# Altium schematic sheet PCIe_Switch.SchDoc
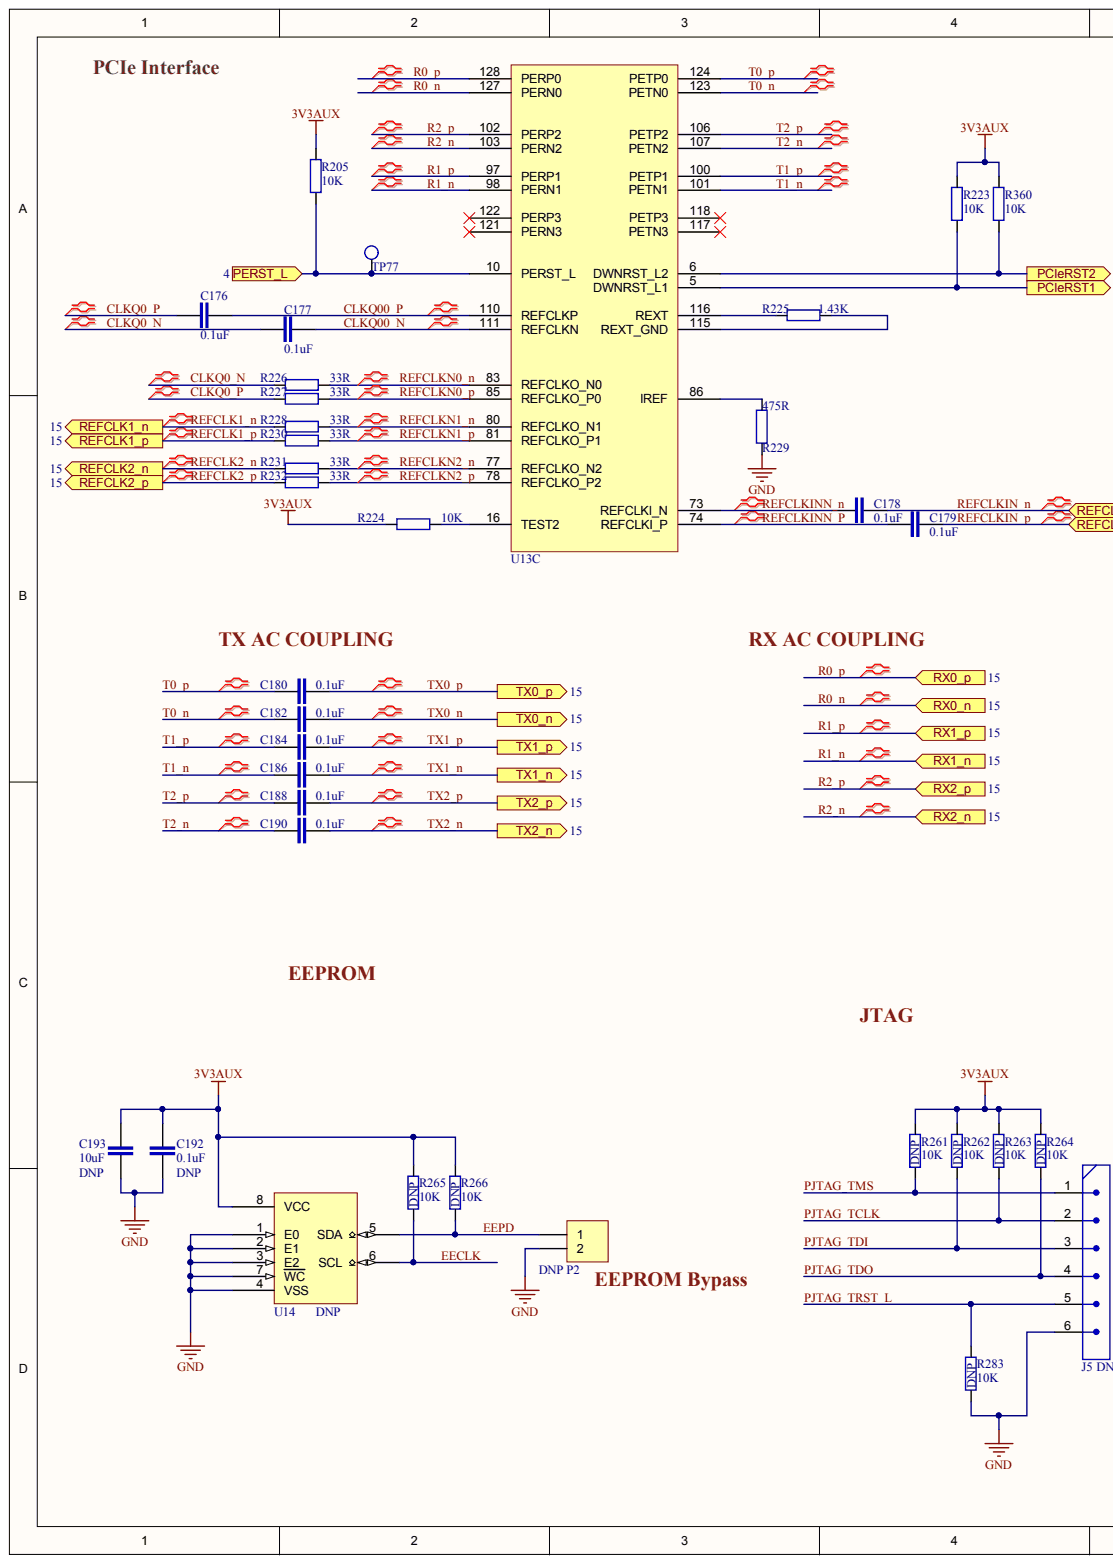
[diagram p0: PCIe_Switch.SchDoc, left]
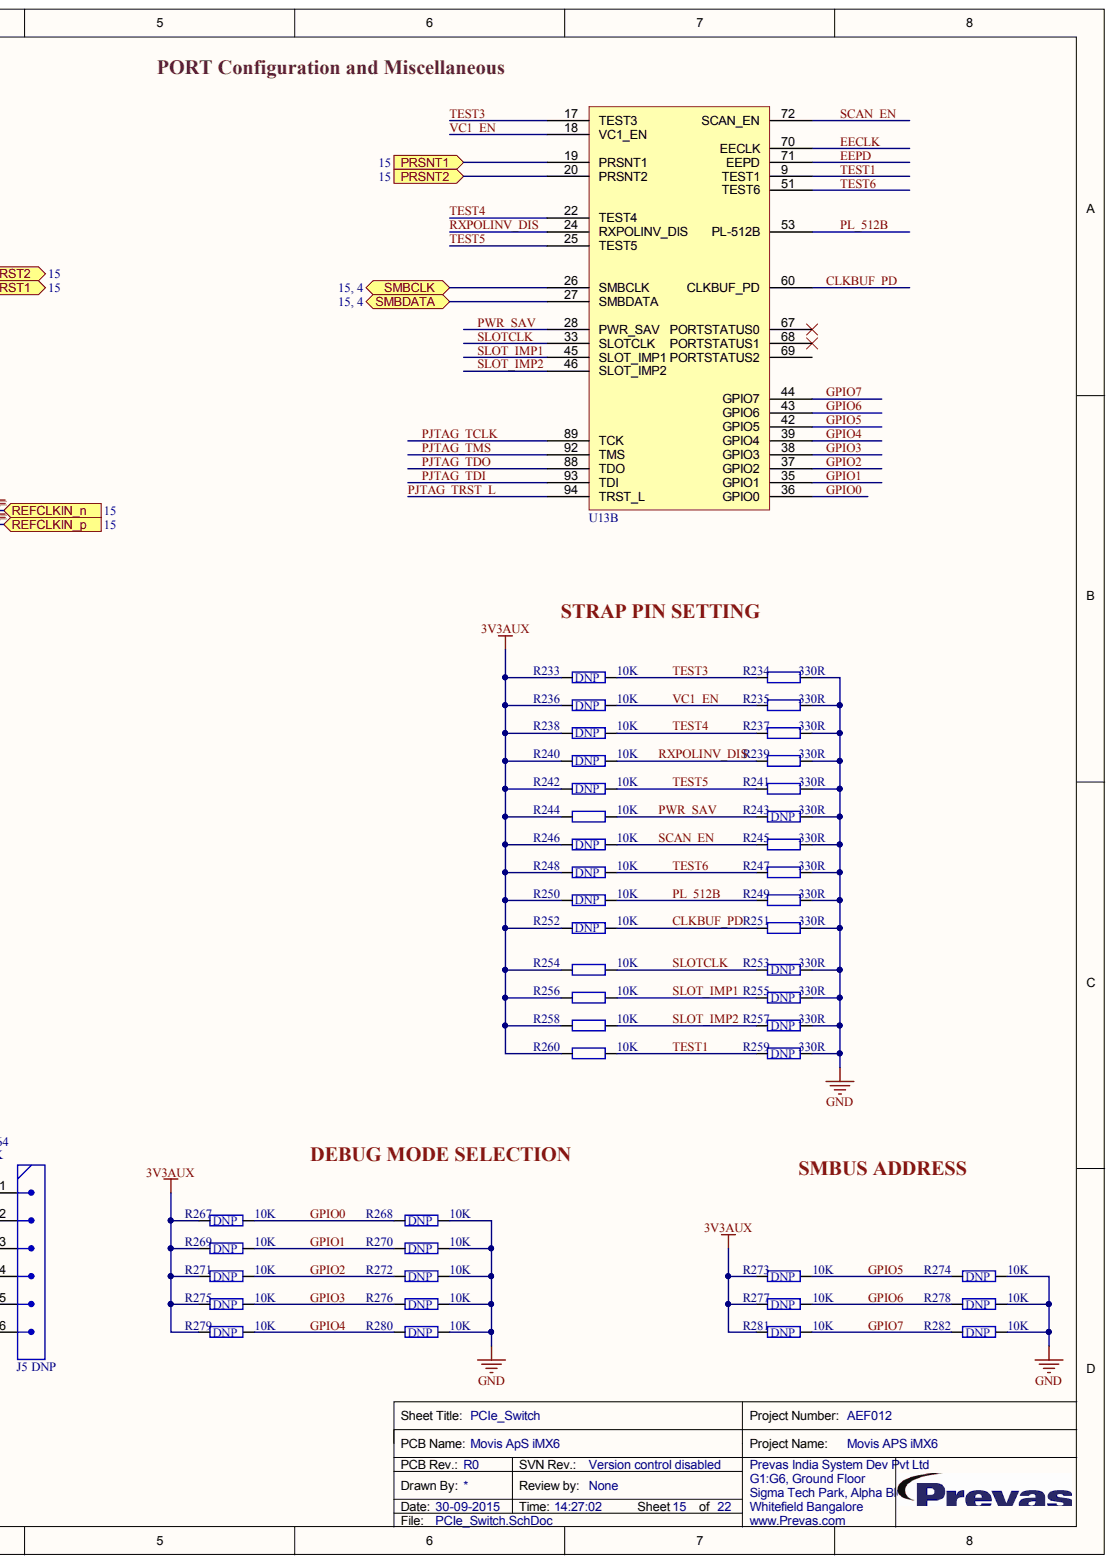
[diagram p1: PCIe_Switch.SchDoc, right]

## Parts
C176  0.1uF  [CAPC1005X55N]
C177  0.1uF  [CAPC1005X55N]
C178  0.1uF  [CAPC1005X55N]
C179  0.1uF  [CAPC1005X55N]
C180  0.1uF  [CAPC1005X55N]
C182  0.1uF  [CAPC1005X55N]
C184  0.1uF  [CAPC1005X55N]
C186  0.1uF  [CAPC1005X55N]
C188  0.1uF  [CAPC1005X55N]
C190  0.1uF  [CAPC1005X55N]
C192  0.1uF  Fitted: DNP  [CAPC1005X55N]
C193  10uF  Fitted: DNP  [CAPC1608X95N]
J5  Fitted: DNP  [MOLEX_53398-6]  (hidden comment: HDR_6)
P2  Fitted: DNP  [MOLEX_53398-2]  (hidden comment: 533980271)
R205  10K  [RESC1608X55N]
R223  10K  [RESC1608X55N]
R224  10K  [RESC1608X55N]
R225  1.43K  [RESC1608X55N]
R226  33R  [RESC1608X55N]
R227  33R  [RESC1608X55N]
R228  33R  [RESC1608X55N]
R229  475R  [RESC1608X55N]
R230  33R  [RESC1608X55N]
R231  33R  [RESC1608X55N]
R232  33R  [RESC1608X55N]
R233  10K  Fitted: DNP  [RESC1608X55N]
R234  330R  [RESC1608X55N]
R235  330R  [RESC1608X55N]
R236  10K  Fitted: DNP  [RESC1608X55N]
R237  330R  [RESC1608X55N]
R238  10K  Fitted: DNP  [RESC1608X55N]
R239  330R  [RESC1608X55N]
R240  10K  Fitted: DNP  [RESC1608X55N]
R241  330R  [RESC1608X55N]
R242  10K  Fitted: DNP  [RESC1608X55N]
R243  330R  Fitted: DNP  [RESC1608X55N]
R244  10K  [RESC1608X55N]
R245  330R  [RESC1608X55N]
R246  10K  Fitted: DNP  [RESC1608X55N]
R247  330R  [RESC1608X55N]
R248  10K  Fitted: DNP  [RESC1608X55N]
R249  330R  [RESC1608X55N]
R250  10K  Fitted: DNP  [RESC1608X55N]
R251  330R  [RESC1608X55N]
R252  10K  Fitted: DNP  [RESC1608X55N]
R253  330R  Fitted: DNP  [RESC1608X55N]
R254  10K  [RESC1608X55N]
R255  330R  Fitted: DNP  [RESC1608X55N]
R256  10K  [RESC1608X55N]
R257  330R  Fitted: DNP  [RESC1608X55N]
R258  10K  [RESC1608X55N]
R259  330R  Fitted: DNP  [RESC1608X55N]
R260  10K  [RESC1608X55N]
R261  10K  Fitted: DNP  [RESC1608X55N]
R262  10K  Fitted: DNP  [RESC1608X55N]
R263  10K  Fitted: DNP  [RESC1608X55N]
R264  10K  Fitted: DNP  [RESC1608X55N]
R265  10K  Fitted: DNP  [RESC1608X55N]
R266  10K  Fitted: DNP  [RESC1608X55N]
R267  10K  Fitted: DNP  [RESC1608X55N]
R268  10K  Fitted: DNP  [RESC1608X55N]
R269  10K  Fitted: DNP  [RESC1608X55N]
R270  10K  Fitted: DNP  [RESC1608X55N]
R271  10K  Fitted: DNP  [RESC1608X55N]
R272  10K  Fitted: DNP  [RESC1608X55N]
R273  10K  Fitted: DNP  [RESC1608X55N]
R274  10K  Fitted: DNP  [RESC1608X55N]
R275  10K  Fitted: DNP  [RESC1608X55N]
R276  10K  Fitted: DNP  [RESC1608X55N]
R277  10K  Fitted: DNP  [RESC1608X55N]
R278  10K  Fitted: DNP  [RESC1608X55N]
R279  10K  Fitted: DNP  [RESC1608X55N]
R280  10K  Fitted: DNP  [RESC1608X55N]
R281  10K  Fitted: DNP  [RESC1608X55N]
R282  10K  Fitted: DNP  [RESC1608X55N]
R283  10K  Fitted: DNP  [RESC1608X55N]
R360  10K  [RESC1608X55N]
TP77  Testpoint  [TP_SMD]  (value not drawn)  (no BOM)
U13  PI7C9X2G304_SCH  [LQFP40P1600X1600X160_HS-129N]  (value not drawn)  (parts B,C)
U14  Fitted: DNP  [SOP65P640X110-8N]  (hidden comment: M24C02-RDW6T)

## Ports
PCIERST1, PCIERST2, PERST_L, PRSNT1, PRSNT2, REFCLK1_N, REFCLK1_P, REFCLK2_N, REFCLK2_P, REFCLKIN_N, REFCLKIN_P, RX0_N, RX0_P, RX1_N, RX1_P, RX2_N, RX2_P, SMBCLK, SMBDATA, TX0_N, TX0_P, TX1_N, TX1_P, TX2_N, TX2_P

## Nets
3V3AUX: C192.2 C193.2 R205.1 R223.1 R224.2 R233.1 R236.1 R238.1 R240.1 R242.1 R244.1 R246.1 R248.1 R250.1 R252.1 R254.1 R256.1 R258.1 R260.1 R261.1 R262.1 R263.1 R264.1 R265.1 R266.1 R267.1 R269.1 R271.1 R273.1 R275.1 R277.1 R279.1 R281.1 R360.1 U14.8(VCC)
GND: C192.1 C193.1 J5.6 P2.2 R229.1 R234.2 R235.2 R237.2 R239.2 R241.2 R243.2 R245.2 R247.2 R249.2 R251.2 R253.2 R255.2 R257.2 R259.2 R268.2 R270.2 R272.2 R274.2 R276.2 R278.2 R280.2 R282.2 R283.2 U14.1(E0) U14.2(E1) U14.3(E2) U14.4(VSS) U14.7(WC)
CLKBUF_PD: R251.1 R252.2 U13.60(CLKBUF_PD)
CLKQ00_N: C177.1 U13.111(REFCLKN)
CLKQ0_N: C177.2 R226.1
CLKQ00_P: C176.1 U13.110(REFCLKP)
CLKQ0_P: C176.2 R227.1
EECLK: R265.2 U13.70(EECLK) U14.6(SCL)
EEPD: P2.1 R266.2 U13.71(EEPD) U14.5(SDA)
GPIO0: R267.2 R268.1 U13.36(GPIO0)
GPIO1: R269.2 R270.1 U13.35(GPIO1)
GPIO2: R271.2 R272.1 U13.37(GPIO2)
GPIO3: R275.2 R276.1 U13.38(GPIO3)
GPIO4: R279.2 R280.1 U13.39(GPIO4)
GPIO5: R273.2 R274.1 U13.42(GPIO5)
GPIO6: R277.2 R278.1 U13.43(GPIO6)
GPIO7: R281.2 R282.1 U13.44(GPIO7)
PERST_L: R205.2 TP77.1 U13.10(PERST_L) ; port PERST_L
PJTAG_TCLK: J5.2 R263.2 U13.89(TCK)
PJTAG_TDI: J5.3 R262.2 U13.93(TDI)
PJTAG_TDO: J5.4 R264.2 U13.88(TDO)
PJTAG_TMS: J5.1 R261.2 U13.92(TMS)
PJTAG_TRST_L: J5.5 R283.1 U13.94(TRST_L)
PL_512B: R249.1 R250.2 U13.53(PL-512B)
PRSNT1: U13.19(PRSNT1) ; port PRSNT1
PRSNT2: U13.20(PRSNT2) ; port PRSNT2
PWR_SAV: R243.1 R244.2 U13.28(PWR_SAV)
R0_N: U13.127(PERN0) ; port RX0_N
R0_P: U13.128(PERP0) ; port RX0_P
R1_N: U13.98(PERN1) ; port RX1_N
R1_P: U13.97(PERP1) ; port RX1_P
R2_N: U13.103(PERN2) ; port RX2_N
R2_P: U13.102(PERP2) ; port RX2_P
REFCLK1_N: R228.1 ; port REFCLK1_N
REFCLK1_P: R230.1 ; port REFCLK1_P
REFCLK2_N: R231.1 ; port REFCLK2_N
REFCLK2_P: R232.1 ; port REFCLK2_P
REFCLKIN_N: C178.1 ; port REFCLKIN_N
REFCLKIN_P: C179.1 ; port REFCLKIN_P
REFCLKINN_N: C178.2 U13.73(REFCLKI_N)
REFCLKINN_P: C179.2 U13.74(REFCLKI_P)
REFCLKN0_N: R226.2 U13.83(REFCLKO_N0)
REFCLKN0_P: R227.2 U13.85(REFCLKO_P0)
REFCLKN1_N: R228.2 U13.80(REFCLKO_N1)
REFCLKN1_P: R230.2 U13.81(REFCLKO_P1)
REFCLKN2_N: R231.2 U13.77(REFCLKO_N2)
REFCLKN2_P: R232.2 U13.78(REFCLKO_P2)
RXPOLINV_DIS: R239.1 R240.2 U13.24(RXPOLINV_DIS)
SCAN_EN: R245.1 R246.2 U13.72(SCAN_EN)
SLOT_IMP1: R255.1 R256.2 U13.45(SLOT_IMP1)
SLOT_IMP2: R257.1 R258.2 U13.46(SLOT_IMP2)
SLOTCLK: R253.1 R254.2 U13.33(SLOTCLK)
SMBCLK: U13.26(SMBCLK) ; port SMBCLK
SMBDATA: U13.27(SMBDATA) ; port SMBDATA
T0_N: C182.2 U13.123(PETN0)
T0_P: C180.2 U13.124(PETP0)
T1_N: C186.2 U13.101(PETN1)
T1_P: C184.2 U13.100(PETP1)
T2_N: C190.2 U13.107(PETN2)
T2_P: C188.2 U13.106(PETP2)
TEST1: R259.1 R260.2 U13.9(TEST1)
TEST3: R233.2 R234.1 U13.17(TEST3)
TEST4: R237.1 R238.2 U13.22(TEST4)
TEST5: R241.1 R242.2 U13.25(TEST5)
TEST6: R247.1 R248.2 U13.51(TEST6)
TX0_N: C182.1 ; port TX0_N
TX0_P: C180.1 ; port TX0_P
TX1_N: C186.1 ; port TX1_N
TX1_P: C184.1 ; port TX1_P
TX2_N: C190.1 ; port TX2_N
TX2_P: C188.1 ; port TX2_P
VC1_EN: R235.1 R236.2 U13.18(VC1_EN)
NetR223_2: R223.2 U13.5(DWNRST_L1) ; port PCIERST1
NetR224_1: R224.1 U13.16(TEST2)
NetR225_1: R225.1 U13.116(REXT)
NetR225_2: R225.2 U13.115(REXT_GND)
NetR229_2: R229.2 U13.86(IREF)
NetR360_2: R360.2 U13.6(DWNRST_L2) ; port PCIERST2

Not connected: U13 67(PORTSTATUS0) 68(PORTSTATUS1) 69(PORTSTATUS2) 117(PETN3) 118(PETP3) 121(PERN3) 122(PERP3)

## Library data of the parts
C176-C180 C182 C184 C186 C188 C190 C192 = INNISI-73: CAP CER 0.1UF 50V 10% X5R 0402; ref_part_type: /capacitor/non_polarized/ceramic/; source_manufacturer_1: TDK Corporation; source_manufacturer_1_pn: C1005X5R1H104K050BB; cae_altium_pcb_status: Prototype; Database Table Name: altium_default; db_rev: 7569; elec_temp_range: -55 to +85 C; phy_height: 0.55mm; phy_mounting: SMD; phy_packaging_type: 0402; ref_datasheet: INNISI-71; cae_altium_sch_status: Prototype; Component Kind: Standard; ComponentLink1Description: Datasheet; ComponentLink1URL: https://cop201.prevas.dk/viewvc/datasheet/INNISI-71.pdf?root=hw_components&view=co; db_created: 2015-08-03 03:34:59.0; db_last_updated: 03-08-2015 03:35:01; db_local_id: INNISI-73; db_status: Prototype; elec_tolerance: ±10%; elec_value: 0.1uF; elec_voltage_rating: 50V; phy_material: X5R; ref_keyword: CAP CER 0.1UF 50V 10% X5R 0402; Library Name: altium_mysql.dblib
C193 = INNISI-78: CAP CER 10UF 16V 10% X5R 0603; ref_part_type: /capacitor/non_polarized/ceramic/; source_manufacturer_1: Murata; source_manufacturer_1_pn: GRM188R61C106KAALD; cae_altium_pcb_status: Prototype; Database Table Name: altium_default; db_rev: 7574; elec_temp_range: -55 to +85C; phy_height: 0.95mm; phy_mounting: SMD; phy_packaging_type: 0603; ref_datasheet: INNISI-75; ref_keyword: Ceramic capacitor X5R 0603; cae_altium_sch_status: Prototype; Component Kind: Standard; ComponentLink1Description: Datasheet; ComponentLink1URL: https://cop201.prevas.dk/viewvc/datasheet/INNISI-75.pdf?root=hw_components&view=co; db_created: 2015-08-03 05:08:42.0; db_last_updated: 03-08-2015 05:08:44; db_local_id: INNISI-78; db_status: Prototype; elec_tolerance: 10%; elec_value: 10uF; elec_voltage_rating: 16V; phy_material: X5R; Library Name: altium_mysql.dblib
J5 = SOMO-114: PicoBlade header 6-pin; ref_part_type: /connector/6 pins/; source_manufacturer_1: Molex; cae_altium_pcb_status: Prototype; Database Table Name: altium_default; db_rev: 4602; elec_current_rating: 1A; elec_temp_range: -40 to +85°C; elec_value: 533980671; elec_voltage_rating: 125V; phy_height: 4.7mm; phy_material: High temperature thermoplastic; phy_mounting: SMD; phy_packaging_type: Vertical; ref_datasheet: MITO-22; cae_altium_sch_status: Prototype; Component Kind: Standard; ComponentLink1Description: Datasheet; ComponentLink1URL: https://cop201.prevas.dk/viewvc/datasheet/MITO-22.pdf?root=hw_components&view=co; db_created: 2012-05-09 15:21:49.0; db_last_updated: 09-05-2012 16:30:37; db_local_id: SOMO-114; db_status: Prototype; ref_keyword: Header; source_manufacturer_1_pn: 533980671; Library Name: altium_mysql.dblib
P2 = MITO-27: PicoBlade header 2-pin; ref_part_type: /connector/2 pins/; source_manufacturer_1: Molex; cae_altium_pcb_status: Prototype; Database Table Name: altium_default; db_rev: 1871; elec_current_rating: 1A; elec_temp_range: -40 to +85°C; elec_voltage_rating: 125V; phy_height: 4.7mm; phy_material: High temperature thermoplastic; phy_mounting: SMD; phy_packaging_type: Vertical; ref_datasheet: MITO-21; cae_altium_sch_status: Prototype; Component Kind: Standard; ComponentLink1Description: Datasheet; ComponentLink1URL: https://cop201.prevas.dk/viewvc/datasheet/MITO-21.pdf?root=hw_components&view=co; db_created: 2008-10-13 12:04:23; db_last_updated: 16-10-2009 09:56:08; db_local_id: MITO-27; db_status: Prototype; elec_value: 533980271; ref_keyword: Header; source_manufacturer_1_pn: 533980271; Library Name: altium_mysql.dblib
R205 R223 R224 R233 R236 R238 R240 R242 R244 R246 R248 R250 R252 R254 R256 R258 R260-R283 R360 = INVIHA-3: RES SMD 10K OHM 1% 1/10W 0603; ref_part_type: /resistor/fixed/; source_manufacturer_1: Yageo; source_manufacturer_1_pn: RC0603FR-0710KL; cae_altium_pcb_status: Prototype; Database Table Name: altium_default; db_rev: 7575; elec_power_rating: 0.1W; elec_temp_range: -55 to +155° C; phy_height: 0.55mm; phy_packaging_type: 0603; ref_datasheet: INVIHA-2; ref_keyword: Chip Resistor; cae_altium_sch_status: Prototype; Component Kind: Standard; ComponentLink1Description: Datasheet; ComponentLink1URL: https://cop201.prevas.dk/viewvc/datasheet/INVIHA-2.pdf?root=hw_components&view=co; db_created: 2015-08-03 05:39:03.0; db_last_updated: 03-08-2015 5:39:05 AM; db_local_id: INVIHA-3; db_status: Prototype; elec_tolerance: 1%; elec_value: 10K; phy_mounting: SMD; Library Name: altium_mysql.dblib
  R233 R236 R238 R240 R242 R244 R246 R248 R250 R252 R254 R256 R258 R260-R283: Mounted: Yes
R225 = INVIHA-25: RES SMD 1.43K OHM 1% 1/10W 0603; ref_part_type: /resistor/fixed/; source_manufacturer_1: Yageo; source_manufacturer_1_pn: RC0603FR-071K43L; cae_altium_pcb_status: Prototype; Database Table Name: altium_default; db_rev: 7615; elec_power_rating: 0.1W; elec_temp_range: -55 to +155° C; phy_height: 0.55mm; phy_packaging_type: 0603; ref_keyword: Chip Resistor; cae_altium_sch_status: Prototype; Component Kind: Standard; ComponentLink1Description: Datasheet; ComponentLink1URL: https://cop201.prevas.dk/viewvc/datasheet/INVIHA-2.pdf?root=hw_components&view=co; db_created: 2015-08-03 08:20:30.0; db_last_updated: 03-08-2015 8:20:32 AM; db_local_id: INVIHA-25; db_status: Prototype; elec_tolerance: 1%; elec_value: 1.43K; phy_mounting: SMD; ref_datasheet: INVIHA-2; Library Name: altium_mysql.dblib
R226-R228 R230-R232 = INVIHA-13: RES SMD 33 OHM 1% 1/10W 0603; ref_part_type: /resistor/fixed/; source_manufacturer_1: Yageo; source_manufacturer_1_pn: RC0603FR-0733RL; cae_altium_pcb_status: Prototype; Database Table Name: altium_default; db_rev: 7593; elec_power_rating: 0.1W; elec_temp_range: -55 to +155° C; phy_height: 0.55mm; phy_packaging_type: 0603; ref_datasheet: INVIHA-2; ref_keyword: Chip Resistor; cae_altium_sch_status: Prototype; Component Kind: Standard; ComponentLink1Description: Datasheet; ComponentLink1URL: https://cop201.prevas.dk/viewvc/datasheet/INVIHA-2.pdf?root=hw_components&view=co; db_created: 2015-08-03 06:49:16.0; db_last_updated: 03-08-2015 6:49:17 AM; db_local_id: INVIHA-13; db_status: Prototype; elec_tolerance: 1%; elec_value: 33R; phy_mounting: SMD; Library Name: altium_mysql.dblib
R229 = INVIHA-26: RES SMD 475 OHM 1% 1/10W 0603; ref_part_type: /resistor/fixed/; source_manufacturer_1: Yageo; source_manufacturer_1_pn: RC0603FR-07475RL; cae_altium_pcb_status: Prototype; Database Table Name: altium_default; db_rev: 7661; elec_power_rating: 0.1W; elec_temp_range: -55 to +155° C; phy_height: 0.55mm; phy_packaging_type: 0603; ref_keyword: Chip Resistor; cae_altium_sch_status: Prototype; Component Kind: Standard; ComponentLink1Description: Datasheet; ComponentLink1URL: https://cop201.prevas.dk/viewvc/datasheet/INVIHA-2.pdf?root=hw_components&view=co; db_created: 2015-08-03 08:23:01.0; db_last_updated: 04-08-2015 9:41:54 AM; db_local_id: INVIHA-26; db_status: Prototype; elec_tolerance: 1%; elec_value: 475R; phy_mounting: SMD; ref_datasheet: INVIHA-2; Library Name: altium_mysql.dblib
R234 R235 R237 R239 R241 R243 R245 R247 R249 R251 R253 R255 R257 R259 = INVIHA-27: RES SMD 330 OHM 1% 1/10W 0603; ref_part_type: /resistor/fixed/; source_manufacturer_1: Yageo; source_manufacturer_1_pn: RC0603FR-07330RL; cae_altium_pcb_status: Prototype; Database Table Name: altium_default; db_rev: 7617; elec_power_rating: 0.1W; elec_temp_range: -55 to +155° C; phy_height: 0.55mm; phy_packaging_type: 0603; ref_keyword: Chip Resistor; cae_altium_sch_status: Prototype; Component Kind: Standard; ComponentLink1Description: Datasheet; ComponentLink1URL: https://cop201.prevas.dk/viewvc/datasheet/INVIHA-2.pdf?root=hw_components&view=co; db_created: 2015-08-03 08:26:38.0; db_last_updated: 03-08-2015 8:26:40 AM; db_local_id: INVIHA-27; db_status: Prototype; elec_tolerance: 1%; elec_value: 330R; phy_mounting: SMD; ref_datasheet: INVIHA-2; Library Name: altium_mysql.dblib
TP77 = JEPE-114: Test Point SMD; ref_part_type: /pcb_item/; cae_altium_pcb_status: Prototype; Database Table Name: altium_default; db_rev: 3281; cae_altium_sch_status: Prototype; ComponentLink1Description: Datasheet; db_created: 2008-08-18 11:39:42; db_last_updated: 23-03-2011 14:14:06; db_local_id: JEPE-114; db_status: Prototype; elec_value: Test Point; phy_mounting: SMD; ref_keyword: Test  Point; Library Name: altium_mysql.dblib
U13 = INNISI-104: PCI Interface IC 3 port 4 lane PCIe 2.0 Packet Switch; ref_part_type: /integrated/digital/interface/; source_manufacturer_1: Pericom; cae_altium_pcb_status: Prototype; Database Table Name: altium_default; db_rev: 7752; elec_frequency_rating: 100 MHz; elec_power_rating: 0.65 W; elec_temp_range: -40 C - +85 C; elec_value: PI7C9X2G304SLBFDEX; elec_voltage_rating: 3.3 V; phy_mounting: SMD; phy_packaging_type: LQFP-128; ref_datasheet: INNISI-89; ref_keyword: Switch - PCIe; cae_altium_sch_status: Prototype; Component Kind: Standard; ComponentLink1Description: Datasheet; ComponentLink1URL: https://cop201.prevas.dk/viewvc/datasheet/INNISI-89.pdf?root=hw_components&view=co; db_created: 2015-08-18 05:29:31.0; db_last_updated: 18-08-2015 06:25:36; db_local_id: INNISI-104; db_status: Prototype; source_manufacturer_1_pn: PI7C9X2G304SLBFDEX; Library Name: altium_mysql.dblib
U14 = NIMA-69: 2Kx8 I2C bus serial EEPROM; ref_part_type: /integrated/digital/memory/non_volatile/eraseable/eeprom/; source_manufacturer_1: STMicroelectronics; cae_altium_pcb_status: Prototype; Database Table Name: altium_default; db_rev: 1268; elec_frequency_rating: 400kHz; elec_temp_range: -40 to +85°C; elec_voltage_rating: 1.8-5.5V; phy_height: 1.1mm; phy_mounting: SMD; phy_packaging_type: TSSOP8; ref_datasheet: NIMA-30; ref_keyword: I2C Serial Flash; cae_altium_sch_status: Prototype; Component Kind: Standard; ComponentLink1Description: Datasheet; ComponentLink1URL: https://cop201.prevas.dk/viewvc/datasheet/NIMA-30.pdf?root=hw_components&view=co; db_created: 2009-04-28 23:23:20; db_last_updated: 28-04-2009 23:31:00; db_local_id: NIMA-69; db_status: Prototype; elec_value: M24C02-RDW6T; source_manufacturer_1_pn: M24C02-RDW6T; Library Name: altium_mysql.dblib
